# Altium schematic sheet NODE_V1.SchDoc
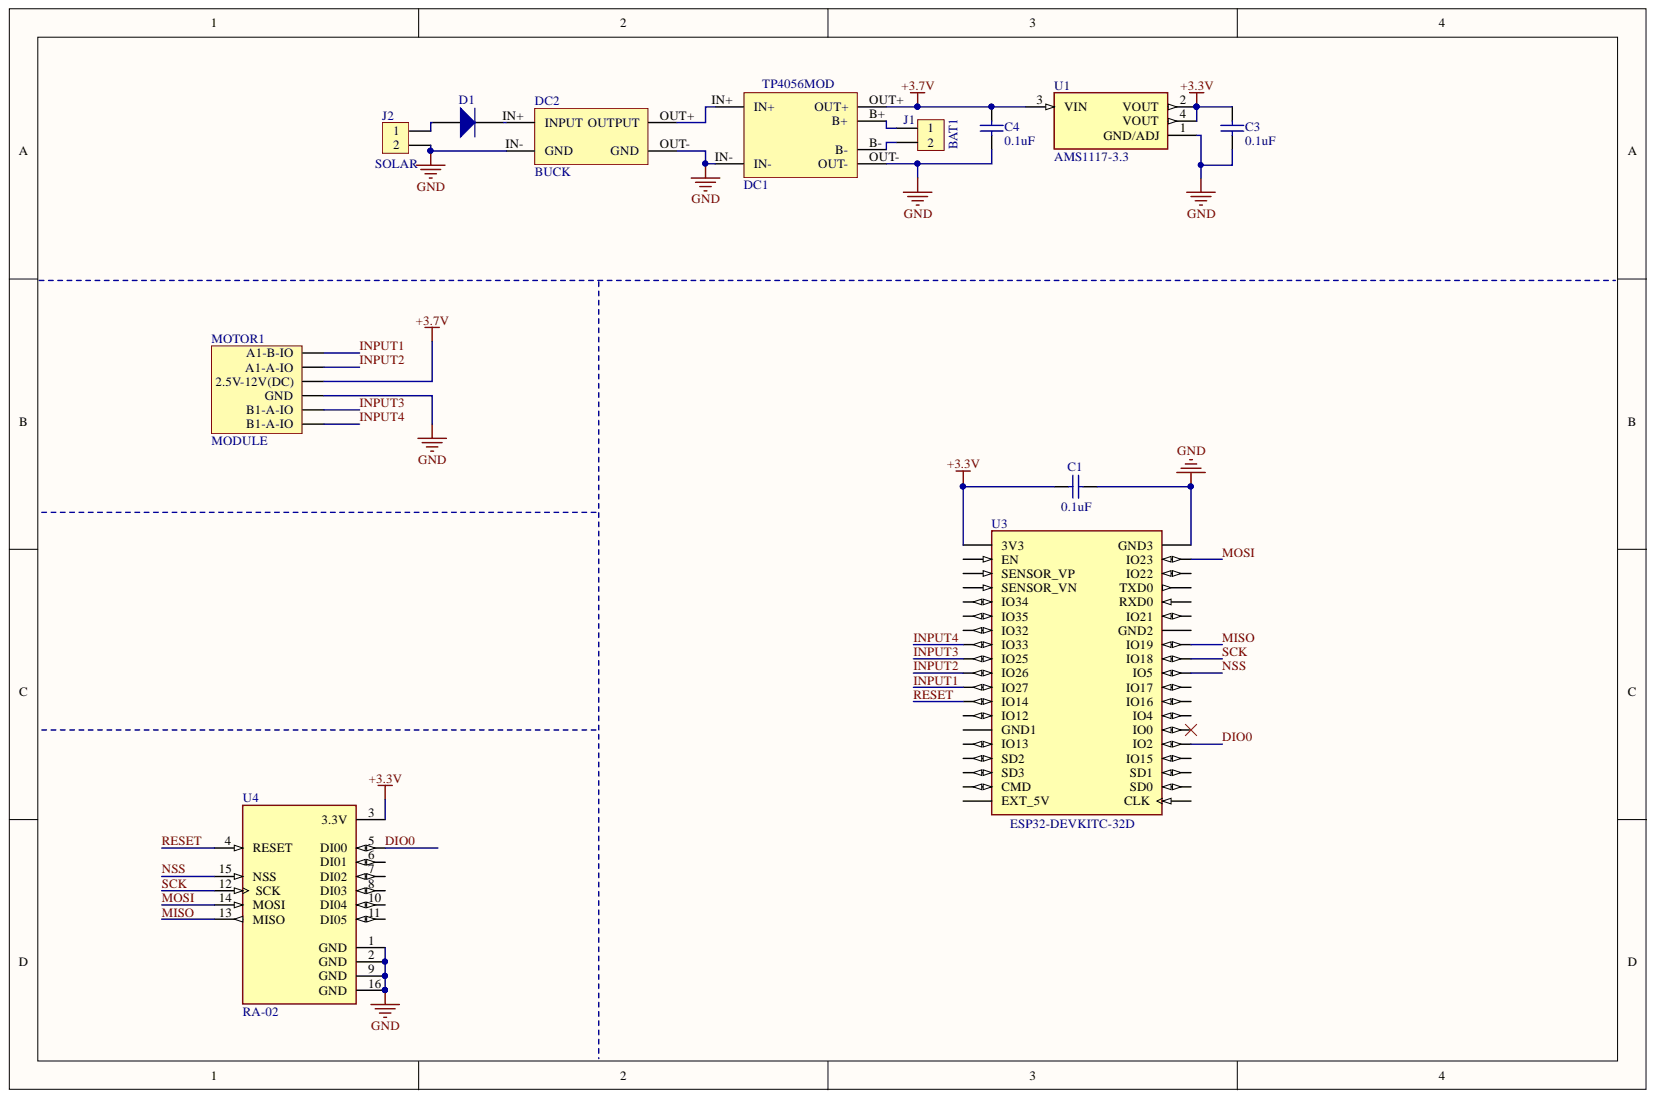
[diagram p0: NODE_V1.SchDoc]

## Parts
C1  value 0.1uF  [C1206]  (hidden comment: Cap)
C3  value 0.1uF  [C1206]  (hidden comment: Cap)
C4  value 0.1uF  [C1206]  (hidden comment: Cap)
D1  Diode  [D10]  (value not drawn)
DC1  TP4056MOD  [TP4056MOD]
DC2  BUCK  [BUCK]
J1  BAT1  [BAT_BK-18650-PC2]
J2  PackageReference: SOLAR  [PH2]  (hidden comment: 5.08mm)
MOTOR1  MODULE  [L9110S]
U1  AMS1117-3.3  [SOT223_M]
U3  ESP32-DEVKITC-32D  [ESP32-DEVKITC-32D]
U4  RA-02  [LORACHIP]

## Nets
+3.3V: C1.1 C3.2 U1.2(VOUT) U1.4(VOUT) U3.J2-1(3V3) U4.3(3.3V)
+3.7V: C4.2 DC1.OUT+ MOTOR1.3(2.5V-12V(DC)) U1.3(VIN)
GND: C1.2 C3.1 C4.1 DC1.IN- DC1.OUT- DC2.IN- DC2.OUT- J2.2 MOTOR1.4(GND) U1.1(GND/ADJ) U3.J3-1(GND3) U4.1(GND) U4.2(GND) U4.9(GND) U4.16(GND)
DIO0: U3.J3-15(IO2) U4.5(DI00)
INPUT1: MOTOR1.1(A1-B-IO) U3.J2-11(IO27)
INPUT2: MOTOR1.2(A1-A-IO) U3.J2-10(IO26)
INPUT3: MOTOR1.5(B1-A-IO) U3.J2-9(IO25)
INPUT4: MOTOR1.6(B1-A-IO) U3.J2-8(IO33)
MISO: U3.J3-8(IO19) U4.13(MISO)
MOSI: U3.J3-2(IO23) U4.14(MOSI)
NSS: U3.J3-10(IO5) U4.15(NSS)
RESET: U3.J2-12(IO14) U4.4(RESET)
SCK: U3.J3-9(IO18) U4.12(SCK)
NetD1_1: D1.1 J2.1
NetD1_2: D1.2 DC2.IN+(INPUT)
NetDC1_B+: DC1.B+ J1.1
NetDC1_B-: DC1.B- J1.2
NetDC1_IN+: DC1.IN+ DC2.OUT+(OUTPUT)

Not connected: U3 J2-2(EN) J2-3(SENSOR_VP) J2-4(SENSOR_VN) J2-5(IO34) J2-6(IO35) J2-7(IO32) J2-13(IO12) J2-14(GND1) J2-15(IO13) J2-16(SD2) J2-17(SD3) J2-18(CMD) J2-19(EXT_5V) J3-3(IO22) J3-4(TXD0) J3-5(RXD0) J3-6(IO21) J3-7(GND2) J3-11(IO17) J3-12(IO16) J3-13(IO4) J3-14(IO0) J3-16(IO15) J3-17(SD1) J3-18(SD0) J3-19(CLK); U4 6(DI01) 7(DI02) 8(DI03) 10(DI04) 11(DI05)

## Library data of the parts
C1 C3 C4 = Cap: Capacitor; Component Kind: Standard; LatestRevisionDate: 17-Jul-2002; LatestRevisionNote: Re-released for DXP Platform.; Library Name: Component Devices.SchLib; PackageDescription: Radial Cap, Thru-Hole; 2 Leads; 0.3 in Pin Spacing; PackageReference: RAD-0.3; Published: 8-Jun-2000; Simulation: CAP; Publisher: Altium Limited
D1 = Diode: Default Diode; Code_JEDEC: DO-214-AB; Component Kind: Standard; LatestRevisionDate: 17-Jul-2002; LatestRevisionNote: Re-released for DXP Platform.; Library Name: Component Devices.SchLib; PackageDescription: DO-214-AB/SMC; 2 C-Bend Leads; Body 7.9 x 5.9 mm, inc. leads (LxW); PackageReference: SMC; PackageVersion: Sep-1996; Published: 8-Jun-2000; Simulation: DIODE; Publisher: Altium Limited
DC1 = TP4056MOD: DeviceSetName: TP4056; GateName_1: G$1; Component Kind: Standard; Library Name: Component Devices.SchLib; SymbolName_1: TP4056; LibraryName: 32teeth - TP4056 Module
J1 J2 = H2: Component Kind: Standard; Library Name: Component Devices.SchLib
  J1: PackageReference: SRW TER-ST
MOTOR1 = L9110S: Component Kind: Standard; Library Name: Component Devices.SchLib
U1 = AMS1117-3.3: Sot223/Pkg 1-Amp 3.3-Volt Low Drop Out Voltage Regulatator; MF: Advanced Monolithic Systems; Availability: Not in stock; Check_prices: https://www.snapeda.com/parts/AMS1117-3.3/Advanced+Monolithic+Systems/view-part/?ref=eda; Component Kind: Standard; Library Name: Component Devices.SchLib; MP: AMS1117-3.3; Package: SOT-223 Seeed Technology; Price: None; SnapEDA_Link: https://www.snapeda.com/parts/AMS1117-3.3/Advanced+Monolithic+Systems/view-part/?ref=snap
U3 = ESP32-DEVKITC-32D: WiFi Development Tools (802.11) ESP32 General Development Kit, ESP32-WROOM-32D on the board; MF: Espressif Systems; Check_prices: https://www.snapeda.com/parts/ESP32-DEVKITC-32D/Espressif+Systems/view-part/?ref=eda; Component Kind: Standard; Library Name: Component Devices.SchLib; MP: ESP32-DEVKITC-32D; Package: None; SnapEDA_Link: https://www.snapeda.com/parts/ESP32-DEVKITC-32D/Espressif+Systems/view-part/?ref=snap
U4 = RA-02: General ISM < 1GHz LoRaâ„¢ Transceiver Module 410MHz ~ 525MHz Antenna Not Included, I-PEX Surface Mount; MF: Ai-Thinker; Availability: Not in stock; Check_prices: https://www.snapeda.com/parts/RA-02/AI-Thinker/view-part/?ref=eda; Component Kind: Standard; Library Name: Component Devices.SchLib; MP: RA-02; Package: None; Price: None; SnapEDA_Link: https://www.snapeda.com/parts/RA-02/AI-Thinker/view-part/?ref=snap
DC2 = BUCK: Component Kind: Standard; Library Name: Component Devices.SchLib
